# Altium schematic sheet ICSL_PS_Combined_Shared.SchDoc
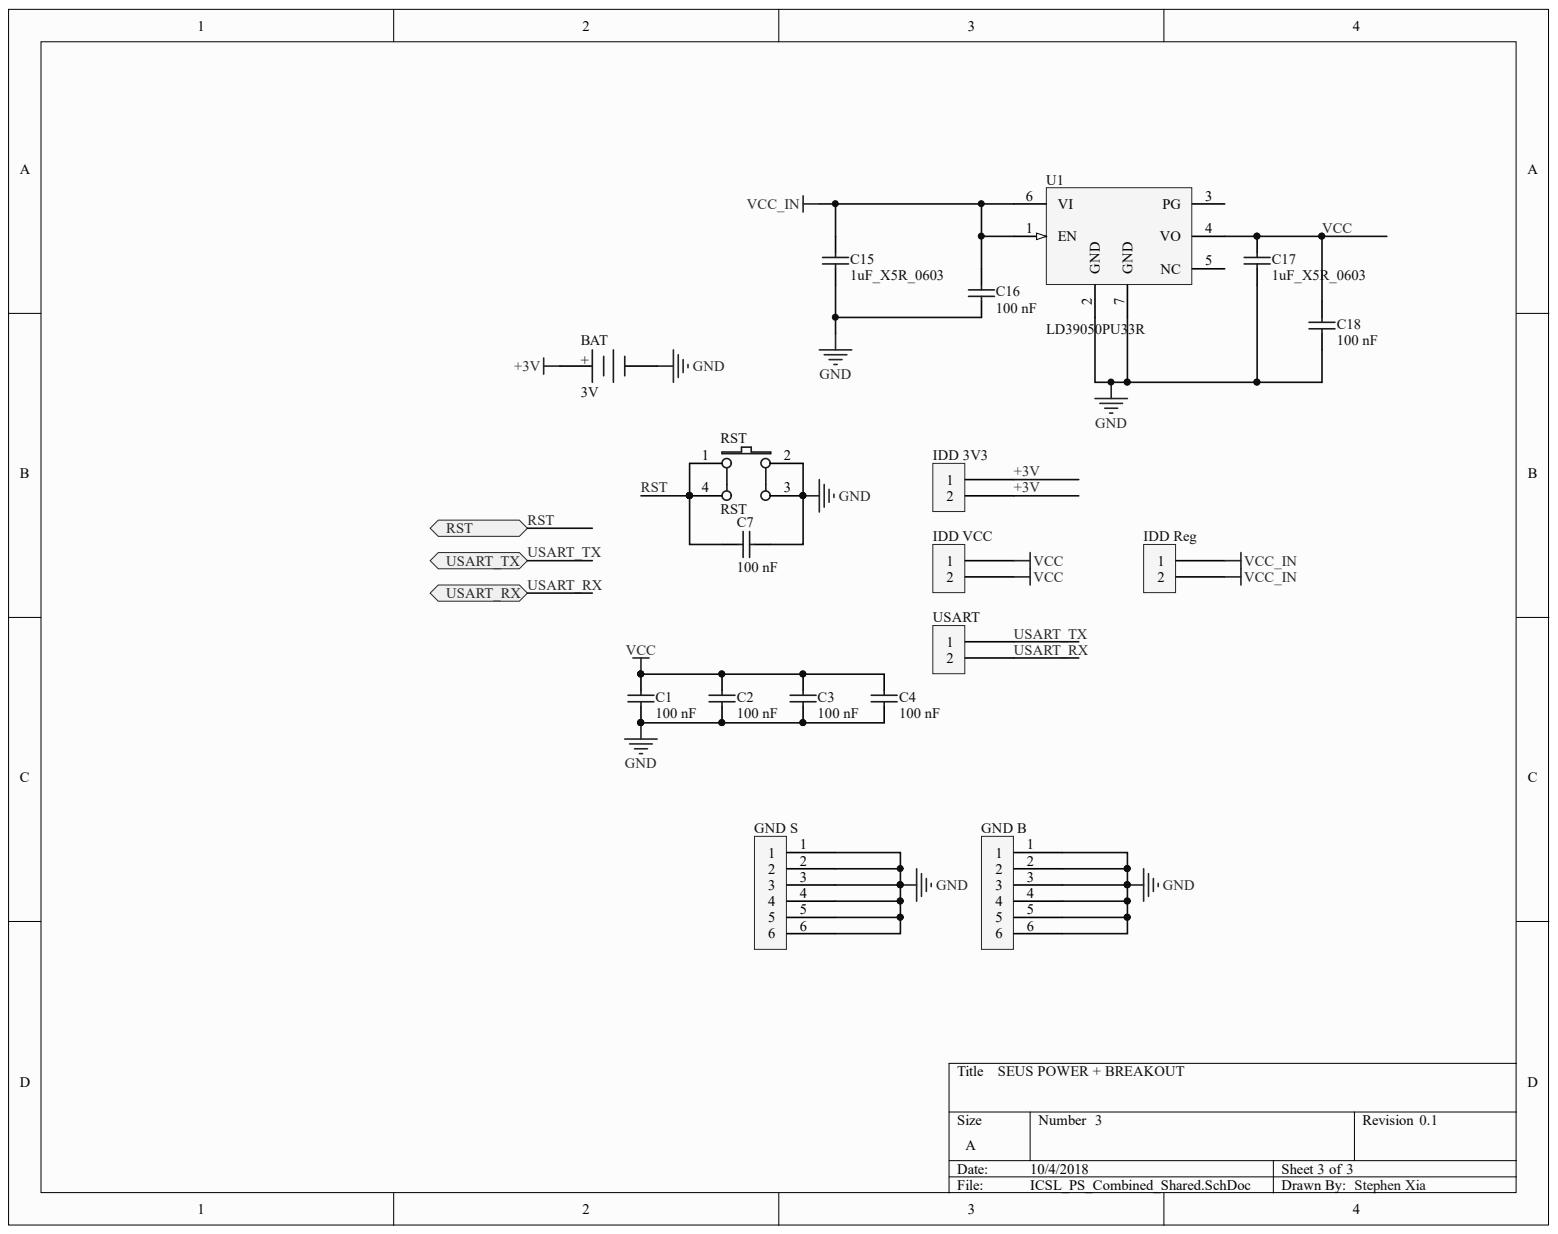
[diagram p0: ICSL_PS_Combined_Shared.SchDoc]

## Parts
BAT  3V  [PCB-ltikzgtn8bqt3ncdf2ka-1]
C1  100 nF  [CAPC1608X87X35LL15T15]
C2  100 nF  [CAPC1608X87X35LL15T15]
C3  100 nF  [CAPC1608X87X35LL15T15]
C4  100 nF  [CAPC1608X87X35LL15T15]
C7  100 nF  [CAPC1608X87X35LL15T15]
C15  1uF_X5R_0603  [CAPC1608X87X35LL15T15]
C16  100 nF  [CAPC1608X87X35LL15T15]
C17  1uF_X5R_0603  [CAPC1608X87X35LL15T15]
C18  100 nF  [CAPC1608X87X35LL15T15]
GND_B  TE Connectivity / AMP 5-146281-6  [PCB-ceua2d6kgzjhmbkiz2sm-1]  (value not drawn)
GND_S  TE Connectivity / AMP 5-146281-6  [PCB-ceua2d6kgzjhmbkiz2sm-1]  (value not drawn)
IDD_3V3  TE Connectivity / AMP 3-644695-2  [PCB-i8m17woc8q0u0fbeoczf-1]  (value not drawn)
IDD_Reg  TE Connectivity / AMP 3-644695-2  [PCB-i8m17woc8q0u0fbeoczf-1]  (value not drawn)
IDD_VCC  TE Connectivity / AMP 3-644695-2  [PCB-i8m17woc8q0u0fbeoczf-1]  (value not drawn)
RST  RST  [BP1CMS]
U1  LD39050PU33R  [DFN6(3X3)_A]
USART  TE Connectivity / AMP 3-644695-2  [PCB-i8m17woc8q0u0fbeoczf-1]  (value not drawn)

## Ports
RST, USART_RX, USART_TX

## Nets
GND: BAT.2 C1.1 C2.1 C3.1 C4.1 C7.2 C15.1 C16.1 C17.1 C18.1 GND_B.1 GND_B.2 GND_B.3 GND_B.4 GND_B.5 GND_B.6 GND_S.1 GND_S.2 GND_S.3 GND_S.4 GND_S.5 GND_S.6 RST.2 RST.3 U1.2(GND) U1.7(GND)
VCC_IN: C15.2 C16.2 IDD_Reg.1 IDD_Reg.2 U1.1(EN) U1.6(VI)
+3V: BAT.1 IDD_3V3.1 IDD_3V3.2
RST: C7.1 RST.1 RST.4 ; port RST
USART_RX: USART.2 ; port USART_RX
USART_TX: USART.1 ; port USART_TX
VCC: C1.2 C2.2 C3.2 C4.2 C17.2 C18.2 IDD_VCC.1 IDD_VCC.2 U1.4(VO)

Not connected: U1 3(PG) 5(NC)

## Library data of the parts
BAT = 1026: HOLDER BATTERY DUAL CELL 20MM; Manufacturer: Keystone Electronics; Lead-Free Status: Lead Free; Wire Gauge: 1.0 AWG; Component Kind: Standard; Contact Plating: Nickel; Library Name: Octopart library; Manufacturer Part Number: 1026; Material: Thermoplastic; OctopartID: 41a888a28f699e6f; Octopart Url: https://octopart.com/1026-keystone-60327; REACH SVHC Compliance: No SVHC; RoHS: Compliant; Size-Diameter: 0.02 m
C1-C4 C7 C15-C18 = C0603C823K5RACTU: Multilayer Ceramic Capacitors MLCC - SMD/SMT 50volts 82000pF X7R 10%; Capacitance: 8.2e-08 F; Lead-Free Status: R; Voltage Rating (DC): 50.0 V; Capacitance Tolerance: ±10%; Case/Package: 0603; Component Kind: Standard; Dielectric Characteristic: X7R; Dielectric Material: Ceramic; Library Name: Octopart library; Mounting Style: Surface Mount; Number of Pins: 2; OctopartID: 386ff1ee6ca5e7a8; Octopart Url: https://octopart.com/c0603c823k5ractu-kemet-9138604; Packaging: Cut Tape (CT); REACH SVHC Compliance: No SVHC; RoHS: Compliant
GND_B GND_S = 5-146281-2: Header; Breakaway; 0.100 in.; PC Board; Single; 6; 0.025 in.; 0.12 in.; 3 A; ELV Compliant: Compliant; Gender: Male; Lead-Free Status: Lead Free; Number of Contacts: 6; Component Kind: Standard; Contact Material: Copper Alloy; Contact Plating: Tin, Tin over Nickel, Gold; Flammability Rating: UL 94 V-0; Housing Color: Black; Library Name: Octopart library; Lifecycle Status: Active; Manufacturer Part Number: 5-146281-2; Mounting Style: PCB; OctopartID: 4f28847cfb2a90ef; Octopart URL: https://octopart.com/5-146281-6-te+connectivity+%2F+amp-40753677; Orientation: Vertical; RoHS: Compliant
IDD_3V3 IDD_Reg IDD_VCC USART = 3-644695-2: Connector Unshrouded Header 2 Position 2.54mm Solder Straight Thru-Hole; ELV Compliant: Compliant; Gender: Male; Lead-Free Status: Lead Free; Mounting Angle: 180 °; Number of Contacts: 2; Shrouded: No; Voltage Rating (AC): 250 V; Component Kind: Standard; Contact Material: Copper Alloy; Contact Plating: Tin; Flammability Rating: UL 94 V-0; Housing Color: Natural; Housing Material: Polyester; Library Name: Octopart library; Lifecycle Status: Active; Manufacturer Part Number: 3-644695-2; Mounting Style: Through Hole; Number of Positions: 2; Number of Rows: 1; OctopartID: 2792ecc2f21af855; Octopart URL: http://octopart.com/3-644695-2-te+connectivity+%2F+amp-39514350; Orientation: Vertical; Packaging: Box, Bag; Pin Pitch: 2.54 mm; RoHS: Compliant; Size-Height: 2.54 mm; Size-Length: 4.80 mm; Size-Width: 2.34 mm
RST = CMP-bffc74333cf8603b-2: Switch Tactile OFF (ON) SPST Round Button Gull Wing 0.05A 24VDC 1.57N SMD T/R; Manufacturer Part Number: 4-1437565-2; Contact Current Rating: 50.0 mA; ELV Compliant: Compliant; Lead-Free Status: Lead Free; Component Kind: Standard; Contact Material: Copper Alloy; Contact Plating: Silver; Contacts Type: SPST; Library Name: CommunityVault; Lifecycle Status: Active; Mounting Style: Surface Mount; OctopartID: bffc74333cf8603b; Octopart Url: http://octopart.com/4-1437565-2-te+connectivity-46507618; Packaging: Tape & Reel (TR); REACH SVHC Compliance: No SVHC; RoHS: Compliant; Seller Landing  Url: https://octopart-clicks.com/click/track?country=US&ak=8157f166&sig=0fb764e&sid=1106&ppid=46507618&vpid=195113533&ct=offers
U1 = LD39050PU33R: LD39050 Series 500 mA 3.3 V Fixed Low Noise LDO Voltage Regulator - DFN-6; Lead-Free Status: Lead Free; Component Kind: Standard; Library Name: Octopart library; Lifecycle Status: Active; Manufacturer Part Number: LD39050PU33R; OctopartID: 9cb95739ca7b437e; Octopart Url: http://octopart.com/ld39050pu33r-stmicroelectronics-42628004; RoHS: Compliant; Seller Landing  Url: https://octopart-clicks.com/click/track?country=US&ak=8157f166&sig=03e676f&sid=1106&ppid=42628004&vpid=119747644&ct=offers
